# Altium schematic sheet Feedback.SchDoc
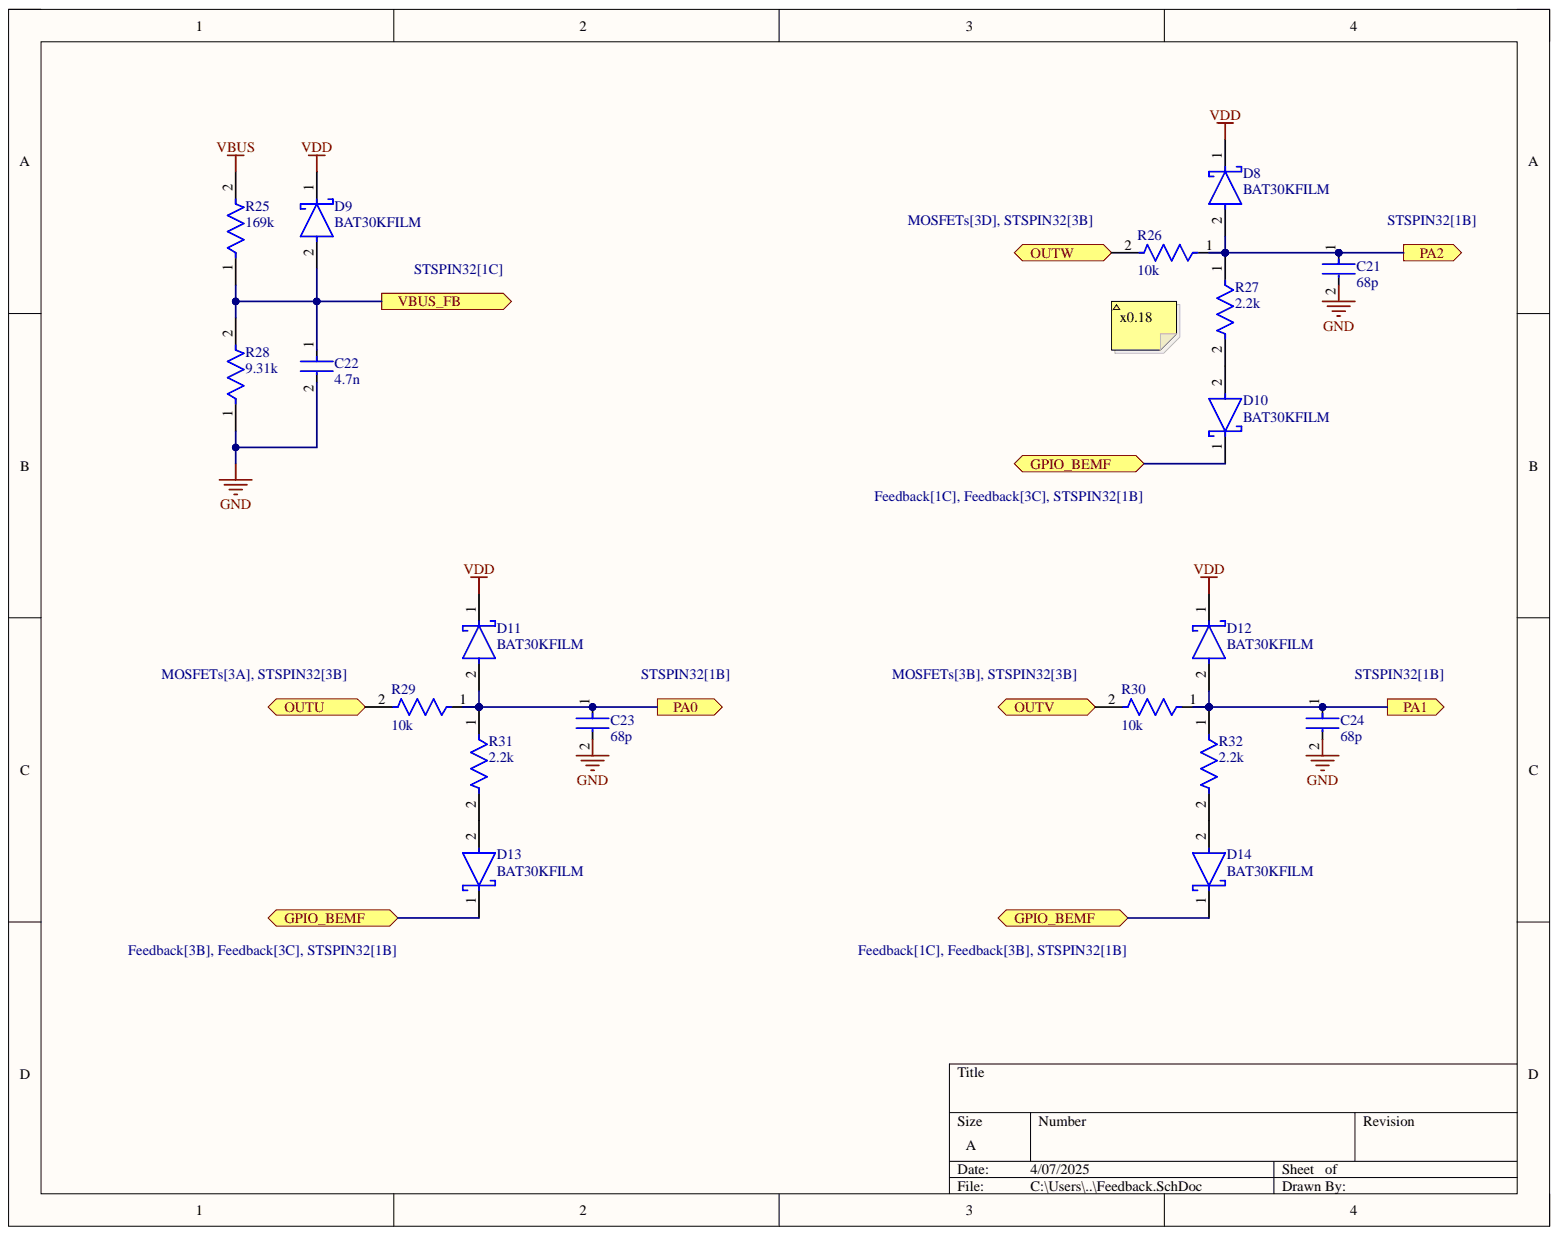
[diagram p0: Feedback.SchDoc]

## Parts
C21  68p  [FP-CC0603-DA-MFG]
C22  4.7n  [FP-CC0603-DA-MFG]
C23  68p  [FP-CC0603-DA-MFG]
C24  68p  [FP-CC0603-DA-MFG]
D8  BAT30KFILM  [FP-SOD-523-IPC_C]
D9  BAT30KFILM  [FP-SOD-523-IPC_C]
D10  BAT30KFILM  [FP-SOD-523-IPC_C]
D11  BAT30KFILM  [FP-SOD-523-IPC_C]
D12  BAT30KFILM  [FP-SOD-523-IPC_C]
D13  BAT30KFILM  [FP-SOD-523-IPC_C]
D14  BAT30KFILM  [FP-SOD-523-IPC_C]
R25  169k  [FP-CRCW0603-e3-IPC_A]
R26  10k  [FP-CRCW0603-e3-IPC_A]
R27  2.2k  [FP-CRCW0603-e3-IPC_A]
R28  9.31k  [FP-CRCW0603-e3-IPC_A]
R29  10k  [FP-CRCW0603-e3-IPC_A]
R30  10k  [FP-CRCW0603-e3-IPC_A]
R31  2.2k  [FP-CRCW0603-e3-IPC_A]
R32  2.2k  [FP-CRCW0603-e3-IPC_A]

## Ports
GPIO_BEMF, OUTU, OUTV, OUTW, PA0, PA1, PA2, VBUS_FB

## Nets
GND: C21.2 C22.2 C23.2 C24.2 R28.1
VBUS: R25.2
VDD: D8.1 D9.1 D11.1 D12.1
GPIO_BEMF: D10.1 D13.1 D14.1 ; port GPIO_BEMF
OUTU: R29.2 ; port OUTU
OUTV: R30.2 ; port OUTV
OUTW: R26.2 ; port OUTW
PA0: C23.1 D11.2 R29.1 R31.1 ; port PA0
PA1: C24.1 D12.2 R30.1 R32.1 ; port PA1
PA2: C21.1 D8.2 R26.1 R27.1 ; port PA2
VBUS_FB: C22.1 D9.2 R25.1 R28.2 ; port VBUS_FB
NetD10_2: D10.2 R27.2
NetD13_2: D13.2 R31.2
NetD14_2: D14.2 R32.2

## Library data of the parts
C21-C24 = CMP-2100-03602-3: CAP CER 0.022UF 50V X7R 0603; Capacitance: 22nF; Dielectric Material: Ceramic; Insulation Resistance: 100GR; Tolerance: 10%; Case Code (Imperial): 0603; Case Code (Metric): 1608; Case/Package: 0603; Component Kind: Standard; Depth: 0.8mm; Dielectric: X7R; Halogen Free: Halogen Free; Height: 0.8mm; Lead Free: Lead Free; Length: 1.6mm; Library Name: Altium Content Vault; Max Operating Temperature: 125°C; Min Operating Temperature: -55°C; Mount: Surface Mount; Number of Pins: 2; Package Quantity: 1; Packaging: Tape and Reel; Radiation Hardening: No; REACH SVHC: No SVHC; Resistor Type: Ceramic; RoHS Compliant: Yes; Series: CC; Termination: SMD/SMT; Thickness: 0.035inch; Voltage: 50V; Voltage Rating: 50V; Voltage Rating (DC): 50V; Width: 0.031inch
D8-D14 = CMP-12187-000035-1: DIODE SCHOTTKY 30V 300MA SOD523; Capacitance: 22pF; Element Configuration: Single; Forward Voltage: 580mV; Max Reverse Current: 50uA; Max Reverse Leakage Current: 5uA; Max Surge Current: 1A; Polarity: Standard; Average Rectified Current: 300mA; Component Kind: Standard; Current Rating: 300mA; Forward Current: 300mA; Height: 0.7mm; Lead Free: Lead Free; Length: 1.3mm; Library Name: Altium Content Vault; Max Operating Temperature: 150°C; Max Repetitive Reverse Voltage (Vrrm): 30V; Max Reverse Voltage (DC): 30V; Min Operating Temperature: -65°C; Mount: Surface Mount; Number of Pins: 2; Output Current: 300mA; Package Quantity: 1; Packaging: Tape and Reel; Peak Non-Repetitive Surge Current: 1A; Radiation Hardening: No; RoHS Compliant: Yes; Series: -; Voltage Rating (DC): 30V; Width: 0.9mm
R25-R32 = CMP-2000-03845-2: RES Thick Film, 10Ω, 1%, 0.1W, 100ppm/°C, 0603; Max Power Dissipation: 100mW; Resistance: 10R; Voltage Rating: 75V; Case Code (Imperial): 0603; Case Code (Metric): 1608; Case/Package: 0603; Component Kind: Standard; Composition: Thick Film; Contact Plating: Tin; Depth: 0.85mm; Features: Automotive AEC-Q200; Height: 0.45mm; Lead Free: Lead Free; Length: 1.55mm; Library Name: Altium Content Vault; Max Operating Temperature: 155°C; Military Standard: Not; Min Operating Temperature: -55°C; Mount: Surface Mount; Number of Pins: 2; Number of Terminations: 2; Package Quantity: 10; Packaging: Tape and Reel; Power Rating: 100mW; Temperature Coefficient: 100ppm/°C; Termination: SMD/SMT; Tolerance: 1%; Voltage Rating (DC): 75V; Weight: 7.1E-05oz; Width: 0.033inch
